# Altium schematic sheet led_clock-MCU.SchDoc
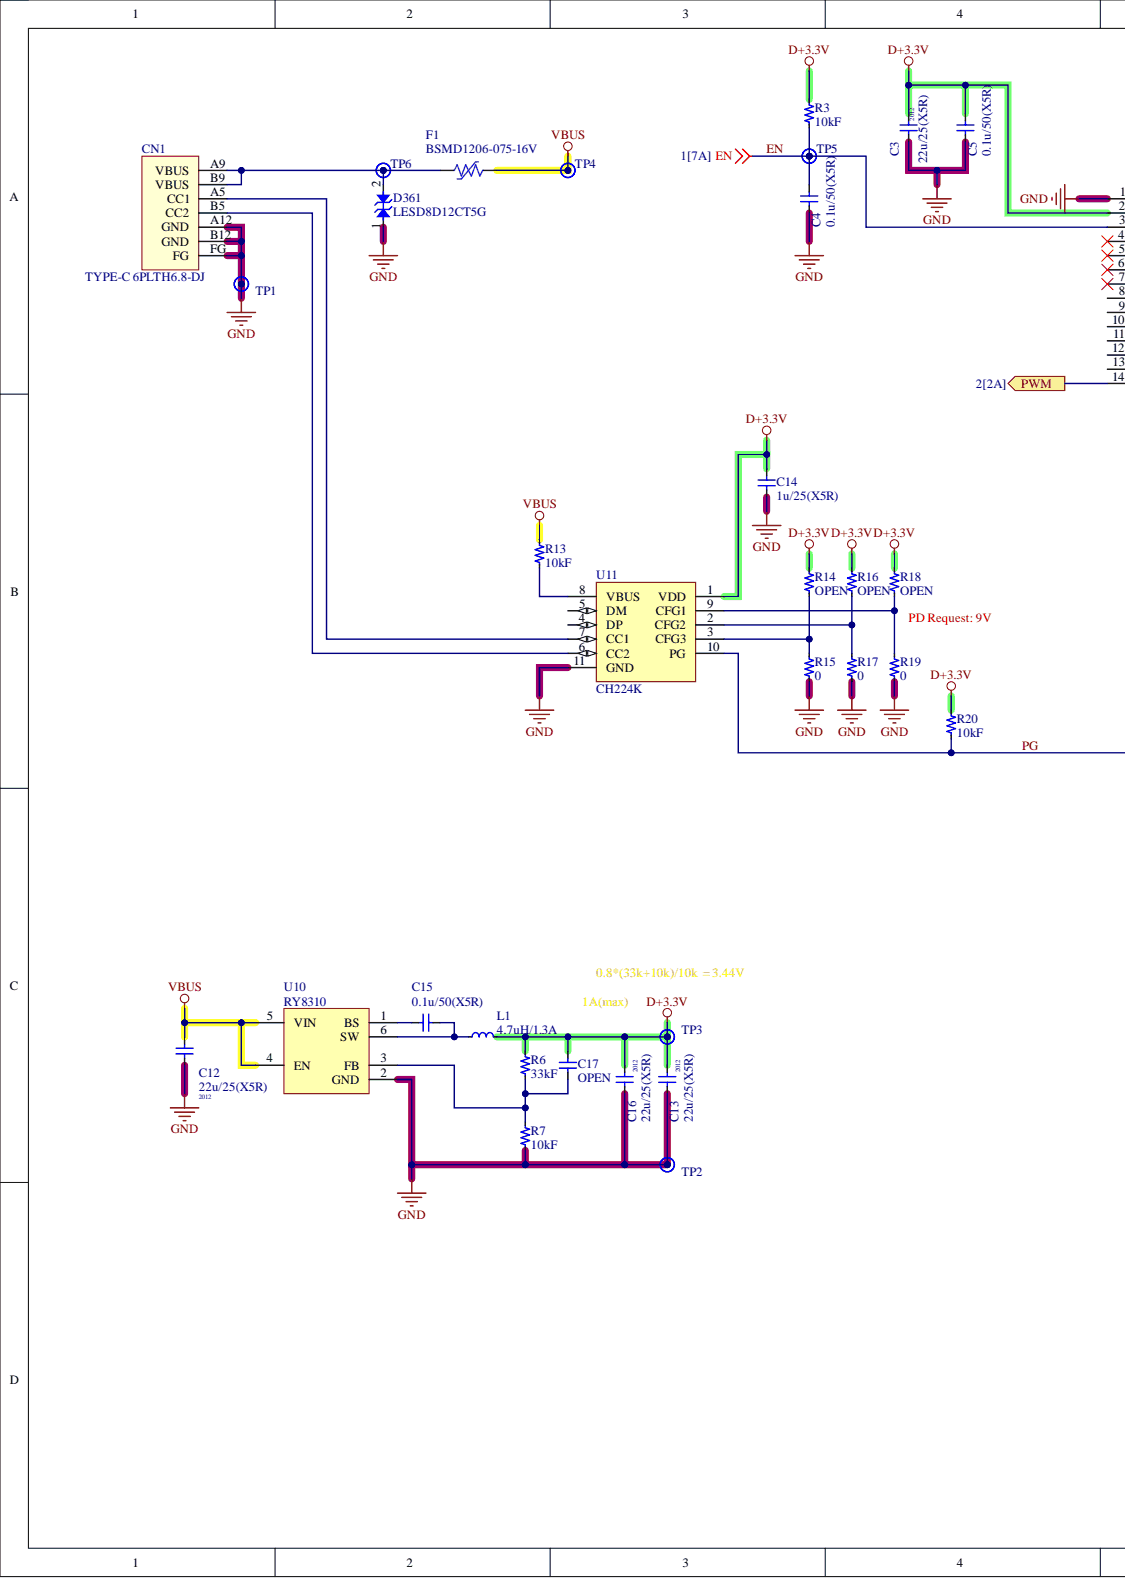
[diagram p0: led_clock-MCU.SchDoc, left]
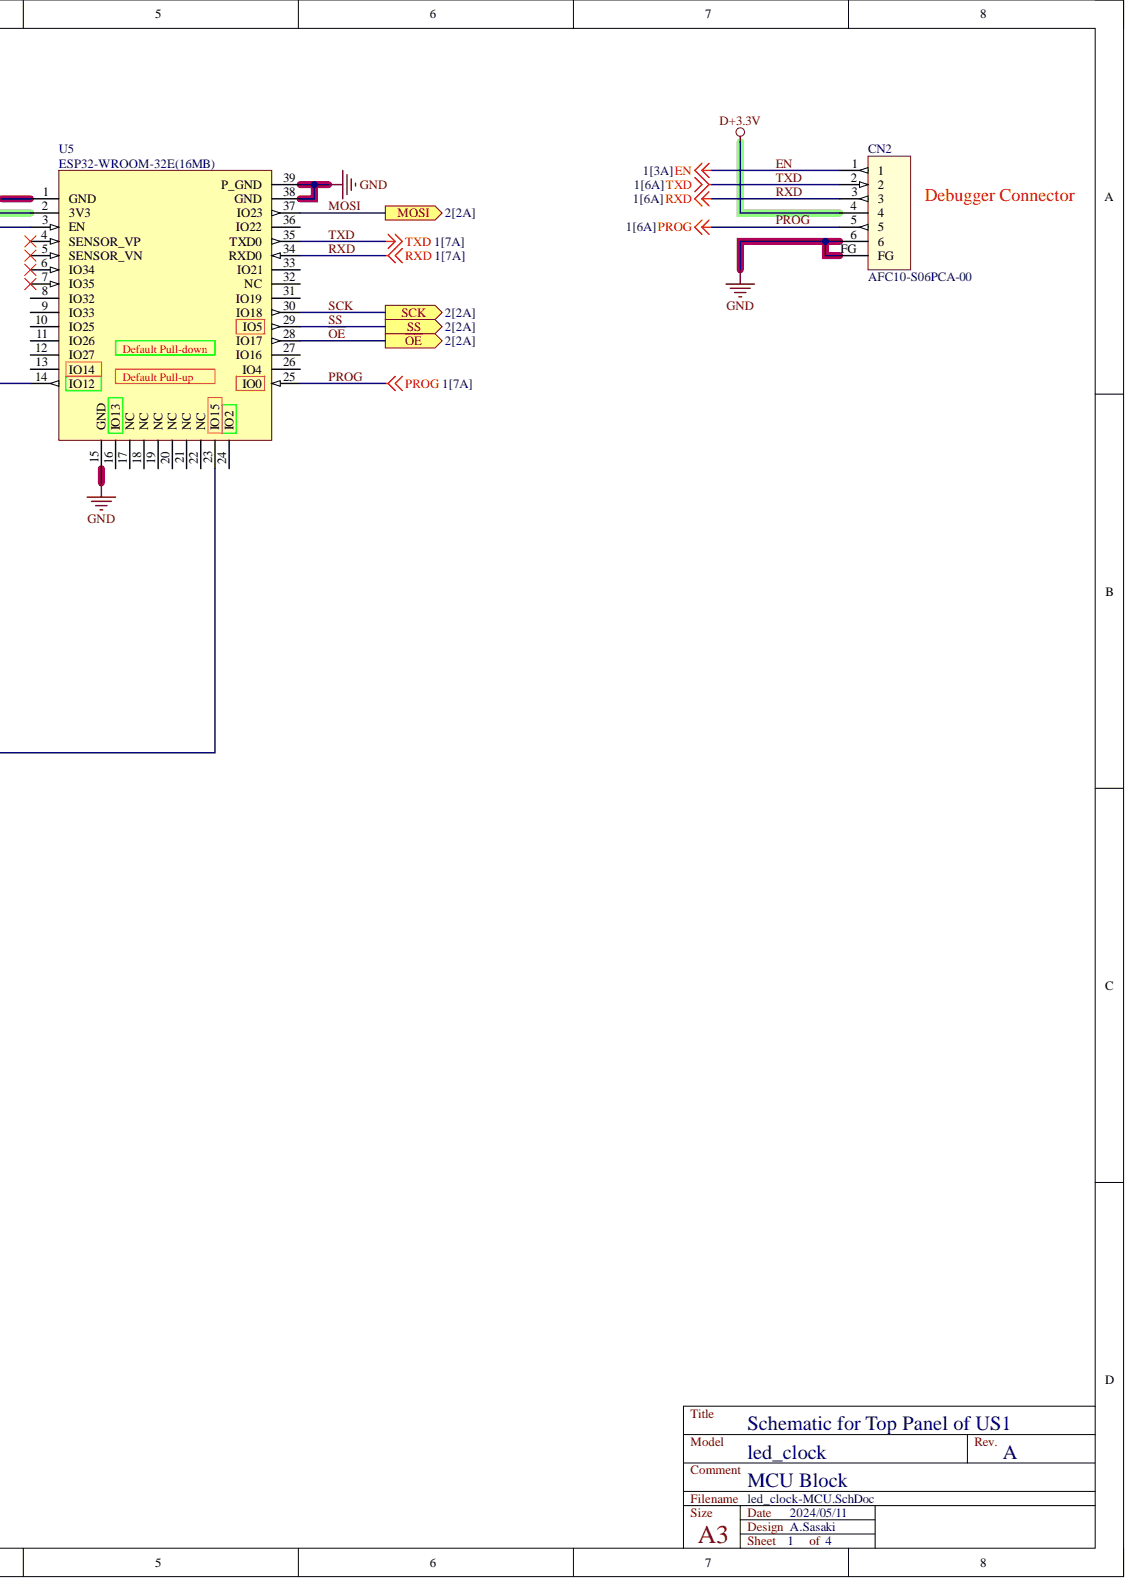
[diagram p1: led_clock-MCU.SchDoc, right]

## Parts
C3  22u/25(X5R)  Size: 2012  [C-METRIC-2012]
C4  0.1u/50(X5R)  [C-METRIC-1005]
C5  0.1u/50(X5R)  [C-METRIC-1005]
C12  22u/25(X5R)  Size: 2012  [C-METRIC-2012]
C13  22u/25(X5R)  Size: 2012  [C-METRIC-2012]
C14  1u/25(X5R)  [C-METRIC-1005]
C15  0.1u/50(X5R)  [C-METRIC-1005]
C16  22u/25(X5R)  Size: 2012  [C-METRIC-2012]
C17  OPEN  [C-METRIC-1005]
CN1  TYPE-C 6PLTH6.8-DJ  [TYPE-C 6PLTH6.8-DJ]
CN2  AFC10-S06PCA-00  [AFC10-S06PCA-00]
D361  LESD8D12CT5G  [SOD882_DFN64P100X53-2N]
F1  BSMD1206-075-16V  [PTCC3217X14N]
L1  4.7uH/1.3A  [INDC2520X12N]
R3  10kF  [R-METRIC-1005]
R6  33kF  [R-METRIC-1005]
R7  10kF  [R-METRIC-1005]
R13  10kF  [R-METRIC-1005]
R14  OPEN  [R-METRIC-1005]
R15  0  [R-METRIC-1005]
R16  OPEN  [R-METRIC-1005]
R17  0  [R-METRIC-1005]
R18  OPEN  [R-METRIC-1005]
R19  0  [R-METRIC-1005]
R20  10kF  [R-METRIC-1005]
TP1  TP-1_0  [TP-1_0_NOSILK_NOPASTE]  (value not drawn)  (no BOM)
TP2  TP-1_0  [TP-1_0_NOSILK_NOPASTE]  (value not drawn)  (no BOM)
TP3  TP-1_0  [TP-1_0_NOSILK_NOPASTE]  (value not drawn)  (no BOM)
TP4  TP-1_0  [TP-1_0_NOSILK_NOPASTE]  (value not drawn)  (no BOM)
TP5  TP-1_0  [TP-1_0_NOSILK_NOPASTE]  (value not drawn)
TP6  TP-1_0  [TP-1_0_NOSILK_NOPASTE]  (value not drawn)  (no BOM)
U5  ESP32-WROOM-32E(16MB)  [ESP32-WROOM-32]
U10  RY8310  [SOT95P280X145-6N]
U11  CH224K  [ESSOP-10_SOP100P600X165_HS-11N]

## Ports
MOSI, PWM, SCK, SS, ~{OE}

## Nets
D+3.3V: C3.2 C5.1 C13.2 C14.2 C16.2 C17.2 CN2.4 L1.2 R3.2 R6.2 R14.1 R16.1 R18.1 R20.2 TP3.1 U5.2(3V3) U11.1(VDD)
GND: C3.1 C4.2 C5.2 C12.1 C13.1 C14.1 C16.1 CN1.A12(GND) CN1.B12(GND) CN1.FG CN2.6 CN2.FG D361.1 R7.1 R15.2 R17.2 R19.2 TP1.1 TP2.1 U5.1(GND) U5.15(GND) U5.38(GND) U5.39(P_GND) U10.2(GND) U11.11(GND)
VBUS: C12.2 F1.2 R13.2 TP4.1 U10.4(EN) U10.5(VIN)
EN: C4.1 CN2.1 R3.1 TP5.1 U5.3(EN)
MOSI: U5.37(IO23) ; port MOSI
~{OE}: U5.28(IO17) ; port ~{OE}
PG: R20.1 U5.23(IO15) U11.10(PG)
PROG: CN2.5 U5.25(IO0)
PWM: U5.14(IO12) ; port PWM
RXD: CN2.3 U5.34(RXD0)
SCK: U5.30(IO18) ; port SCK
SS: U5.29(IO5) ; port SS
TXD: CN2.2 U5.35(TXD0)
NetC15_1: C15.1 U10.1(BS)
NetC15_2: C15.2 L1.1 U10.6(SW)
NetC17_1: C17.1 R6.1 R7.2 U10.3(FB)
NetCN1_A5: CN1.A5(CC1) U11.7(CC1)
NetCN1_A9: CN1.A9(VBUS) CN1.B9(VBUS) D361.2 F1.1 TP6.1
NetCN1_B5: CN1.B5(CC2) U11.6(CC2)
NetR13_1: R13.1 U11.8(VBUS)
NetR14_2: R14.2 R15.1 U11.3(CFG3)
NetR16_2: R16.2 R17.1 U11.2(CFG2)
NetR18_2: R18.2 R19.1 U11.9(CFG1)

Not connected: U5 4(SENSOR_VP) 5(SENSOR_VN) 6(IO34) 7(IO35) 8(IO32) 9(IO33) 10(IO25) 11(IO26) 12(IO27) 13(IO14) 16(IO13) 17(NC) 18(NC) 19(NC) 20(NC) 21(NC) 22(NC) 24(IO2) 26(IO4) 27(IO16) 31(IO19) 32(NC) 33(IO21) 36(IO22); U11 4(DP) 5(DM)

## Library data of the parts
C3 C12 C13 C16 = CL21A226MAQNNNE: CAP CER 22UF 25V X5R 0805(2012metric); Manufacturer: Samsung Electro-Mechanics; Value: 22u; Component Kind: Standard; LCSC Part Number: C45783; Library Name: Samsung.IntLib; Manufacturer Part Number: CL21A226MAQNNNE; Simulation: CAP; Supplier 1: Digi-Key; Supplier 2: Digi-Key; Supplier 3: Digi-Key; Supplier 4: mouser; Supplier Part Number 1: 1276-2908-2-ND; Supplier Part Number 2: 1276-2908-1-ND; Supplier Part Number 3: 1276-2908-6-ND; ValueDisp: 22u/25(X5R)
C4 C5 C15 = CL05B104KB54PNC: CAP CER 0.1UF 50V 10% X7R 0402(1005metric); Manufacturer: Samsung Electro-Mechanics; Value: 0.1u; Component Kind: Standard; LCSC Part Number: C307331; Library Name: Samsung.IntLib; Manufacturer Part Number: CL05B104KB54PNC; Simulation: CAP; Size: 1005; Supplier 1: Digi-Key; Supplier 2: Digi-Key; Supplier 3: Digi-Key; Supplier 4: mouser; Supplier Part Number 1: 1276-CL05B104KB54PNCTR-ND; Supplier Part Number 2: 1276-CL05B104KB54PNCCT-ND; Supplier Part Number 3: 1276-CL05B104KB54PNCDKR-ND; Supplier Part Number 4: 187-CL05B104KB54PNC; ValueDisp: 0.1u/50(X5R)
C14 = CL05A105KA5NQNC: CAP CER 1UF 25V 10% X5R 0402(1005metric); Manufacturer: Samsung Electro-Mechanics; Value: 1u; Component Kind: Standard; LCSC Part Number: C52923; Library Name: Samsung.IntLib; Manufacturer Part Number: CL05A105KA5NQNC; Simulation: CAP; Size: 1005; Supplier 1: Digi-Key; Supplier 2: Digi-Key; Supplier 3: Digi-Key; Supplier 4: mouser; Supplier Part Number 1: 1276-1445-2-ND; Supplier Part Number 2: 1276-1445-1-ND; Supplier Part Number 3: 1276-1445-6-ND; ValueDisp: 1u/25(X5R)
C17 = CL05_OPEN: CAP CER OPEN 0402; Component Kind: Standard; Library Name: Samsung.IntLib; Simulation: CAP; Size: 1005; Supplier 1: Digi-Key; Supplier 2: Digi-Key; Supplier 3: Digi-Key; Supplier 4: mouser; Value: OPEN; ValueDisp: OPEN
CN1 = TYPE-C 6PLTH6.8-DJ: USB TYPE-C,NO DATA, VERT RCPT, PCB MOUNT; Manufacturer: Shenzhen Shouhan Technology Co., Ltd.; Description 2: USB 2.0, TYPE-C, VERT RCPT, PCB MOUNT; Component Kind: Standard; Description 1: TYPE-C 6PLTH6.8-DJ; FirstPublished: 2024/05/06; LCSC Part Number: C5156600; Library Name: Shouhan.IntLib; Manufacturer Part Number: TYPE-C 6PLTH6.8-DJ; Supplier 1: Digi-Key; Supplier 2: MOUSER; Publisher: atsushi sasaki
CN2 = AFC10-S06PCA-00: CONN HEADER SH 6POS TOP 1MM TIN; Manufacturer: Shenzhen Jushuo Electronics Co., Ltd.; Substitute Manufacturer: JST; Substitute Manufacturer Part Number: BM06B-SRSS-TBT(LF)(SN); Component Kind: Standard; Description 1: AFC10-S06PCA-00; Description 2: CONN HEADER SH 6POS TOP 1MM TIN; FirstPublished: 2023/09/02; LCSC Part Number: C2764200; Library Name: JUSHUO.IntLib; Manufacturer Part Number: AFC10-S06PCA-00; Supplier 1: Digi-Key; Supplier 2: Digi-Key; Supplier 3: Digi-Key; Supplier 4: MOUSER; Publisher: Atsushi Sasaki
D361 = LESD8D12CT5G: TVS DIODE 13.3VWM 22VC SOD882; Manufacturer: Leshan Radio; Component Kind: Standard; Description 1: LESD8D12CT5G; Description 2: TVS DIODE 13.3VWM 22VC SOD882; FirstPublished: 2022/04/18; LCSC Part Number: C172407; Library Name: Leshan Radio.IntLib; Manufacturer Part Number: LESD8D12CT5G; Supplier 1: Digi-Key; Supplier 2: Digi-Key; Supplier 3: Digi-Key; Supplier 4: mouser; Publisher: atsushi_sasaki
F1 = BSMD1206-075-16V: PTC RESTTBLE 0.75A 16V CHIP 1206; Manufacturer: SHENZHEN BHFUSE INDUSTRIAL CO., LTD; Component Kind: Standard; Description 1: BSMD1206-075-16V; Description 2: PTC RESTTBLE 0.75A 16V CHIP 1206; FirstPublished: 2023/08/26; LCSC Part Number: C883128; Library Name: BHFUSE.IntLib; Manufacturer Part Number: BSMD1206-075-16V; Supplier 1: Digi-Key; Supplier 2: Digi-Key; Supplier 3: Digi-Key; Supplier 4: MOUSER; Publisher: atsushi.sasaki
L1 = 1239AS-H-4R7M=P2: FIXED IND 4.7UH 1.5A 240 MOHM; Manufacturer: Murata Electronics; Component Kind: Standard; Library Name: murata.IntLib; Manufacturer Part Number: 1239AS-H-4R7M=P2; PackageDescription: Inductor; Published: 2018/01/16; Supplier 1: Digi-Key; Supplier 2: Digi-Key; Supplier 3: Digi-Key; Supplier 4: mouser; Supplier Part Number 1: 490-10568-2-ND; Supplier Part Number 2: 490-10568-1-ND; Supplier Part Number 3: 490-10568-6-ND; Supplier Part Number 4: 81-1239AS-H-4R7MP2; Value: 1239AS-H-4R7M=P2; Publisher: atsushi sasaki
R3 R7 R13 R20 = 0402WGF1002TCE: RES SMD 10K OHM 1% 1/16W 0402; Manufacturer: Uniroyal Elec; Substitute: enable; Component Kind: Standard; LCSC Part Number: C25744; Library Name: Uniroyal.IntLib; Manufacturer Part Number: 0402WGF1002TCE; Simulation: RESISTOR; Size: 1005; Supplier 1: Digi-Key; Supplier 2: Digi-Key; Supplier 3: Digi-Key; Supplier 4: mouser; Value: 10k; Value_disp: 10kF
R6 = 0402WGF3302TCE: RES SMD 33K OHM 1% 1/16W 0402; Manufacturer: Uniroyal Elec; Substitute: enable; Component Kind: Standard; LCSC Part Number: C25779; Library Name: Uniroyal.IntLib; Manufacturer Part Number: 0402WGF3302TCE; Simulation: RESISTOR; Size: 1005; Supplier 1: Digi-Key; Supplier 2: Digi-Key; Supplier 3: Digi-Key; Supplier 4: mouser; Value: 33k; Value_disp: 33kF
R14 R16 R18 = 0402OPEN: RES SMD OPEN 0402; Mounting: No; Component Kind: Standard; Simulation: RESISTOR; Size: 1005; Supplier 1: Digi-Key; Supplier 2: Digi-Key; Supplier 3: Digi-Key; Supplier 4: mouser; Value: OPEN; Value_disp: OPEN; Library Name: Uniroyal.IntLib
R15 R17 R19 = 0402WGF0000TCE: RES SMD 0 OHM 1% 1/16W 0402; Manufacturer: Uniroyal Elec; Substitute: enable; Component Kind: Standard; LCSC Part Number: C17168; Library Name: Uniroyal.IntLib; Manufacturer Part Number: 0402WGF0000TCE; Simulation: RESISTOR; Size: 1005; Supplier 1: Digi-Key; Supplier 2: Digi-Key; Supplier 3: Digi-Key; Supplier 4: mouser; Value: 0; Value_disp: 0
TP1-TP3 = TP-1_0: Mounting: No; Library Name: testpoint.IntLib
TP4 TP6 = TP-1_0: TP ROUND 1.0 mm; Mounting: No; Library Name: testpoint.IntLib
U5 = ESP32-WROOM-32E(16MB): WIFI MODULE 32MBITS SPI FLASH 16MB; Manufacturer: Espressif Systems; Manufacturer Part Number: ESP32-WROOM-32E-N16; Commodity: UART/TTL BLE and WIFI Module with AT Command; Replace: NG; Component Kind: Standard; LCSC Part Number: C701343; Library Name: espressif.IntLib
U10 = RY8310: IC REG BUCK ADJ 1A SYNC TSOT23-6; Manufacturer: RYCHIP Semiconductor Inc.; Component Kind: Standard; Description 1: RY8310; Description 2: IC REG BUCK ADJ 1A SYNC TSOT23-6; FirstPublished: 2019/04/17; LCSC Part Number: C370876; Library Name: Rychip.IntLib; Manufacturer Part Number: RY8310; Supplier 1: Digi-Key; Supplier 2: Digi-Key; Supplier 3: Digi-Key; Supplier 4: MOUSER; Publisher: atsushi_sasaki
U11 = CH224K: IC USB PD CTRL ESSOP10; Manufacturer: WCH(Jiangsu Qin Heng); Component Kind: Standard; Description 1: CH224K; Description 2: IC USB PD CTRL ESSOP10; FirstPublished: 2024/05/02; LCSC Part Number: C970725; Library Name: wch.IntLib; Manufacturer Part Number: CH224K; Supplier 1: Digi-Key; Supplier 2: Digi-Key; Supplier 3: Digi-Key; Supplier 4: MOUSER; Publisher: Atsushi Sasaki
TP5 = TP-1_0: Component Kind: Standard; Library Name: testpoint.IntLib
